# Altium schematic sheet connectors.SchDoc
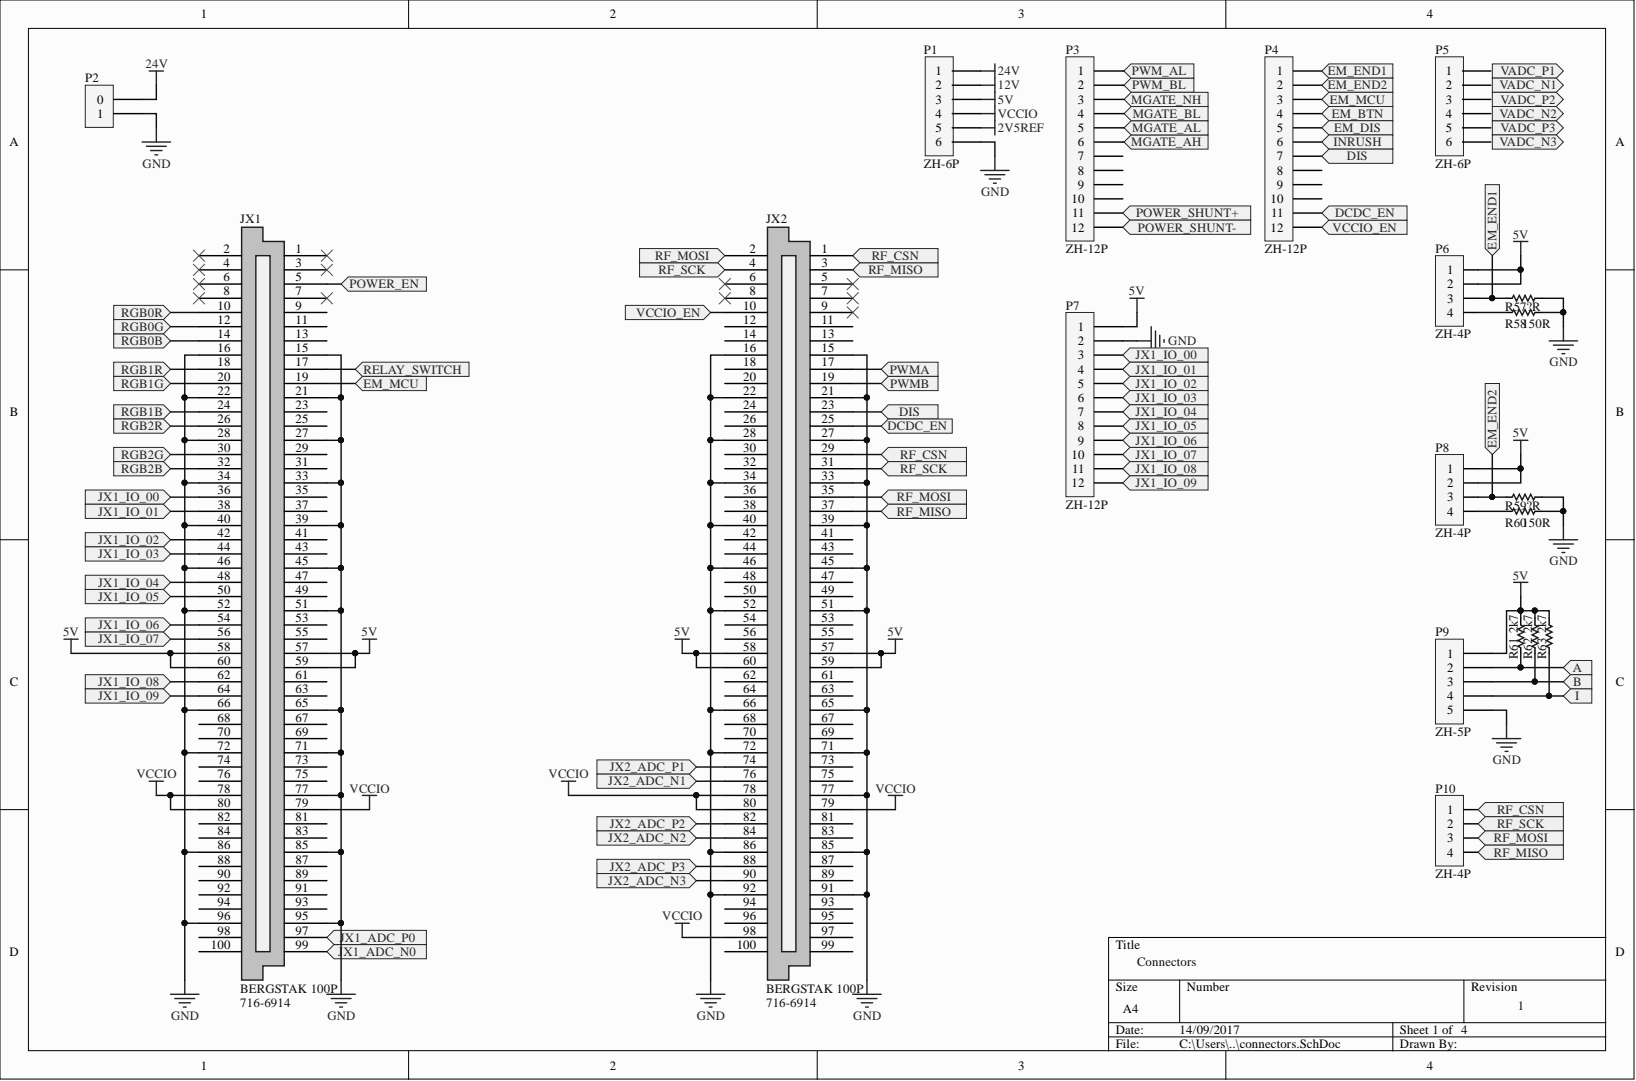
[diagram p0: connectors.SchDoc]

## Parts
JX1  BERGSTAK 100P  Supplier Part Number 1: 716-6914  [FCI_BERGSTAK_100P]
JX2  BERGSTAK 100P  Supplier Part Number 1: 716-6914  [FCI_BERGSTAK_100P]
P1  ZH-6P  [ZH-6P]
P2  2P Terminal  [2P-TERM]  (value not drawn)
P3  ZH-12P  [ZH-12P]
P4  ZH-12P  [ZH-12P]
P5  ZH-6P  [ZH-6P]
P6  ZH-4P  [ZH-4P]
P7  ZH-12P  [ZH-12P]
P8  ZH-4P  [ZH-4P]
P9  ZH-5P  [ZH-5P]
P10  ZH-4P  [ZH-4P]
R57  value ?R  (hidden comment: Resistor)
R58  value 150R  (hidden comment: Resistor)
R59  value ?R  (hidden comment: Resistor)
R60  value 150R  (hidden comment: Resistor)
R61  value 2k7  (hidden comment: Resistor)
R62  value 2k7  (hidden comment: Resistor)
R63  value 2k7  (hidden comment: Resistor)

## Ports
A, B, DCDC_EN, DIS, EM_BTN, EM_DIS, EM_END1, EM_END2, EM_MCU, I, INRUSH, JX1_ADC_N0, JX1_ADC_P0, JX1_IO_00, JX1_IO_01, JX1_IO_02, JX1_IO_03, JX1_IO_04, JX1_IO_05, JX1_IO_06, JX1_IO_07, JX1_IO_08, JX1_IO_09, JX2_ADC_N1, JX2_ADC_N2, JX2_ADC_N3, JX2_ADC_P1, JX2_ADC_P2, JX2_ADC_P3, MGATE_AH, MGATE_AL, MGATE_BL, MGATE_NH, POWER_EN, POWER_SHUNT+, POWER_SHUNT-, PWM_AL, PWM_BL, PWMA, PWMB, RELAY_SWITCH, RF_CSN, RF_MISO, RF_MOSI, RF_SCK, RGB0B, RGB0G, RGB0R, RGB1B, RGB1G, RGB1R, RGB2B, RGB2G, RGB2R, VADC_N1, VADC_N2, VADC_N3, VADC_P1, VADC_P2, VADC_P3, VCCIO_EN

## Nets
2V5REF: P1.5
5V: JX1.57 JX1.58 JX1.59 JX1.60 JX2.57 JX2.58 JX2.59 JX2.60 P1.3 P6.1 P6.2 P7.1 P8.1 P8.2 P9.1 R61.0 R62.0 R63.0
12V: P1.2
24V: P1.1 P2.0
GND: JX1.15 JX1.16 JX1.21 JX1.22 JX1.27 JX1.28 JX1.33 JX1.34 JX1.39 JX1.40 JX1.45 JX1.46 JX1.51 JX1.52 JX1.65 JX1.66 JX1.71 JX1.72 JX1.77 JX1.85 JX1.86 JX1.95 JX1.96 JX2.15 JX2.16 JX2.21 JX2.22 JX2.27 JX2.28 JX2.33 JX2.34 JX2.39 JX2.40 JX2.45 JX2.46 JX2.51 JX2.52 JX2.65 JX2.66 JX2.71 JX2.72 JX2.77 JX2.85 JX2.86 JX2.91 JX2.92 P1.6 P2.1 P7.2 P9.5 R57.0 R58.0 R59.0 R60.0
VCCIO: JX1.78 JX1.79 JX1.80 JX2.78 JX2.79 JX2.80 JX2.98 P1.4
A: P9.2 R61.1 ; port A
B: P9.3 R62.1 ; port B
DCDC_EN: JX2.25 P4.11 ; port DCDC_EN
DIS: JX2.23 P4.7 ; port DIS
EM_BTN: P4.4 ; port EM_BTN
EM_DIS: P4.5 ; port EM_DIS
EM_END1: P4.1 P6.3 R57.1 ; port EM_END1
EM_END2: P4.2 P8.3 R59.1 ; port EM_END2
EM_MCU: JX1.19 P4.3 ; port EM_MCU
I: P9.4 R63.1 ; port I
INRUSH: P4.6 ; port INRUSH
JX1_ADC_N0: JX1.99 ; port JX1_ADC_N0
JX1_ADC_P0: JX1.97 ; port JX1_ADC_P0
JX1_IO_00: JX1.36 P7.3 ; port JX1_IO_00
JX1_IO_01: JX1.38 P7.4 ; port JX1_IO_01
JX1_IO_02: JX1.42 P7.5 ; port JX1_IO_02
JX1_IO_03: JX1.44 P7.6 ; port JX1_IO_03
JX1_IO_04: JX1.48 P7.7 ; port JX1_IO_04
JX1_IO_05: JX1.50 P7.8 ; port JX1_IO_05
JX1_IO_06: JX1.54 P7.9 ; port JX1_IO_06
JX1_IO_07: JX1.56 P7.10 ; port JX1_IO_07
JX1_IO_08: JX1.62 P7.11 ; port JX1_IO_08
JX1_IO_09: JX1.64 P7.12 ; port JX1_IO_09
JX2_ADC_N1: JX2.76 ; port JX2_ADC_N1
JX2_ADC_N2: JX2.84 ; port JX2_ADC_N2
JX2_ADC_N3: JX2.90 ; port JX2_ADC_N3
JX2_ADC_P1: JX2.74 ; port JX2_ADC_P1
JX2_ADC_P2: JX2.82 ; port JX2_ADC_P2
JX2_ADC_P3: JX2.88 ; port JX2_ADC_P3
MGATE_AH: P3.6 ; port MGATE_AH
MGATE_AL: P3.5 ; port MGATE_AL
MGATE_BL: P3.4 ; port MGATE_BL
MGATE_NH: P3.3 ; port MGATE_NH
POWER_EN: JX1.5 ; port POWER_EN
PWM_AL: P3.1 ; port PWM_AL
PWM_BL: P3.2 ; port PWM_BL
PWMA: JX2.17 ; port PWMA
PWMB: JX2.19 ; port PWMB
RELAY_SWITCH: JX1.17 ; port RELAY_SWITCH
RF_CSN: JX2.1 JX2.29 P10.1 ; port RF_CSN
RF_MISO: JX2.3 JX2.37 P10.4 ; port RF_MISO
RF_MOSI: JX2.2 JX2.35 P10.3 ; port RF_MOSI
RF_SCK: JX2.4 JX2.31 P10.2 ; port RF_SCK
RGB0B: JX1.14 ; port RGB0B
RGB0G: JX1.12 ; port RGB0G
RGB0R: JX1.10 ; port RGB0R
RGB1B: JX1.24 ; port RGB1B
RGB1G: JX1.20 ; port RGB1G
RGB1R: JX1.18 ; port RGB1R
RGB2B: JX1.32 ; port RGB2B
RGB2G: JX1.30 ; port RGB2G
RGB2R: JX1.26 ; port RGB2R
VCCIO_EN: JX2.10 P4.12 ; port VCCIO_EN
NetP3_11: P3.11
NetP3_12: P3.12
NetP6_4: P6.4 R58.1
NetP8_4: P8.4 R60.1
N00083: ; port VADC_N2
N00084: ; port VADC_P2
N00085: ; port VADC_N3
N00086: ; port VADC_P3
N00087: ; port VADC_N1
N00088: ; port VADC_P1

Not connected: JX1 1 2 3 4 6 7 8 9 11 13 23 25 29 31 35 37 41 43 47 49 53 55 61 63 67 68 69 70 73 74 75 76 81 82 83 84 87 88 89 90 91 92 93 94 98 100; JX2 5 6 7 8 9 11 12 13 14 18 20 24 26 30 32 36 38 41 42 43 44 47 48 49 50 53 54 55 56 61 62 63 64 67 68 69 70 73 75 81 83 87 89 93 94 95 96 97 99 100; P3 7 8 9 10; P4 8 9 10; P5 1 2 3 4 5 6

## Library data of the parts
JX1 JX2 = BERGSTAK 100P: 100p connector, BERGSTAK; Component Kind: Standard; Supplier 1: RSComponents; Library Name: SDUEmbedded.SchLib
P1 P5 = ZH-6P: Component Kind: Standard; Library Name: SDUEmbedded.SchLib
P2 = 2P Terminal: Component Kind: Standard; Supplier 1: RSComponents; Supplier Part Number 1: 425-8720; Library Name: SDUEmbedded.SchLib
P3 P4 P7 = ZH-12P: Component Kind: Standard; Library Name: SDUEmbedded.SchLib
P6 P8 P10 = ZH-4P: Component Kind: Standard; Library Name: SDUEmbedded.SchLib
P9 = ZH-5P: Component Kind: Standard; Library Name: SDUEmbedded.SchLib
R57-R63 = Resistor: Component Kind: Standard; Description: Resistor; Library Name: SDUEmbedded.SchLib
